# Altium schematic sheet PowerSupply.SchDoc
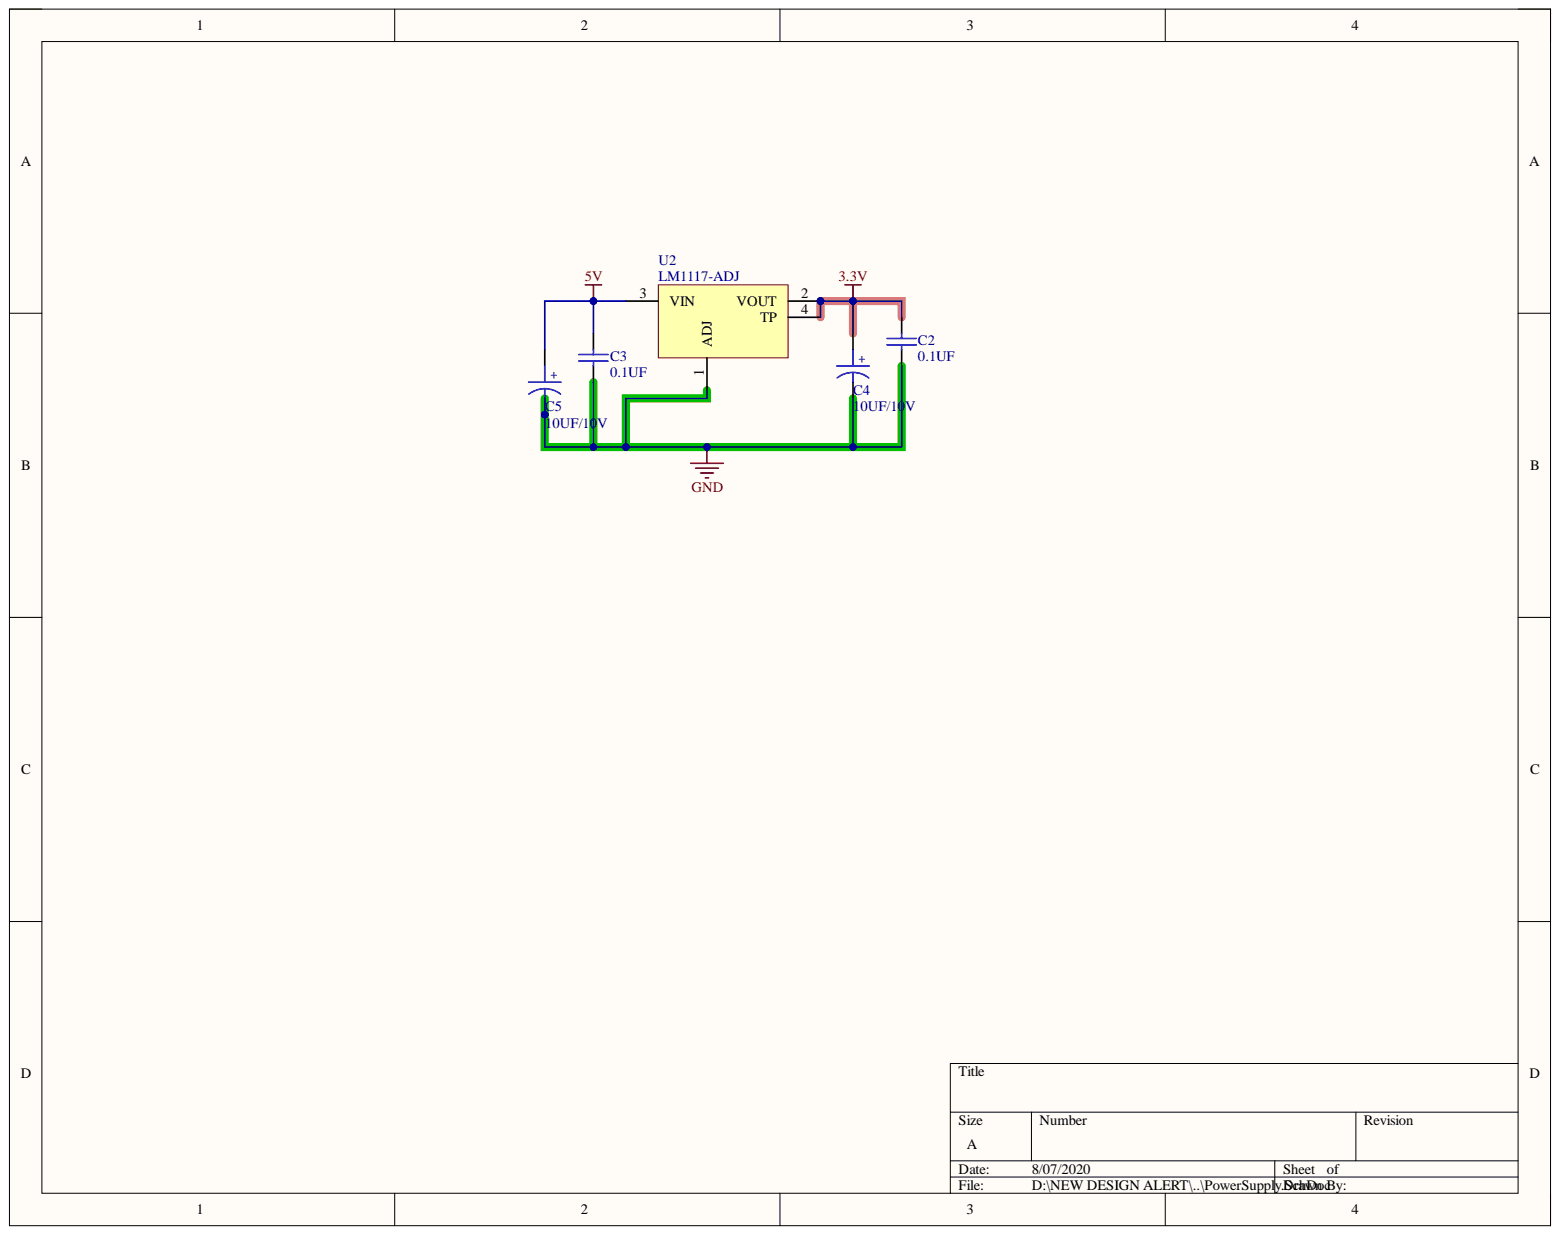
[diagram p0: PowerSupply.SchDoc]

## Parts
C2  0.1UF  [CAPC0603]
C3  0.1UF  [CAPC0603]
C4  10UF/10V  [CAPC1206_TANTALUM]
C5  10UF/10V  [CAPC1206_TANTALUM]
U2  LM1117-ADJ  [SOT223]

## Nets
3.3V: C2.1 C4.1 U2.2(VOUT) U2.4(TP)
5V: C3.1 C5.1 U2.3(VIN)
GND: C2.2 C3.2 C4.2 C5.2 U2.1(ADJ)

## Library data of the parts
C2 C3 = CAP NP: Component Kind: Standard; Library Name: Devices.SchLib
C4 C5 = CAP: Component Kind: Standard; Library Name: Devices.SchLib
U2 = LM1117-3.3V_ADJ: 3.3V LDO REGULATOR; Component Kind: Standard; Library Name: CustomParts.SchLib
